# Altium schematic sheet Inteligent_IGBT.SchDoc
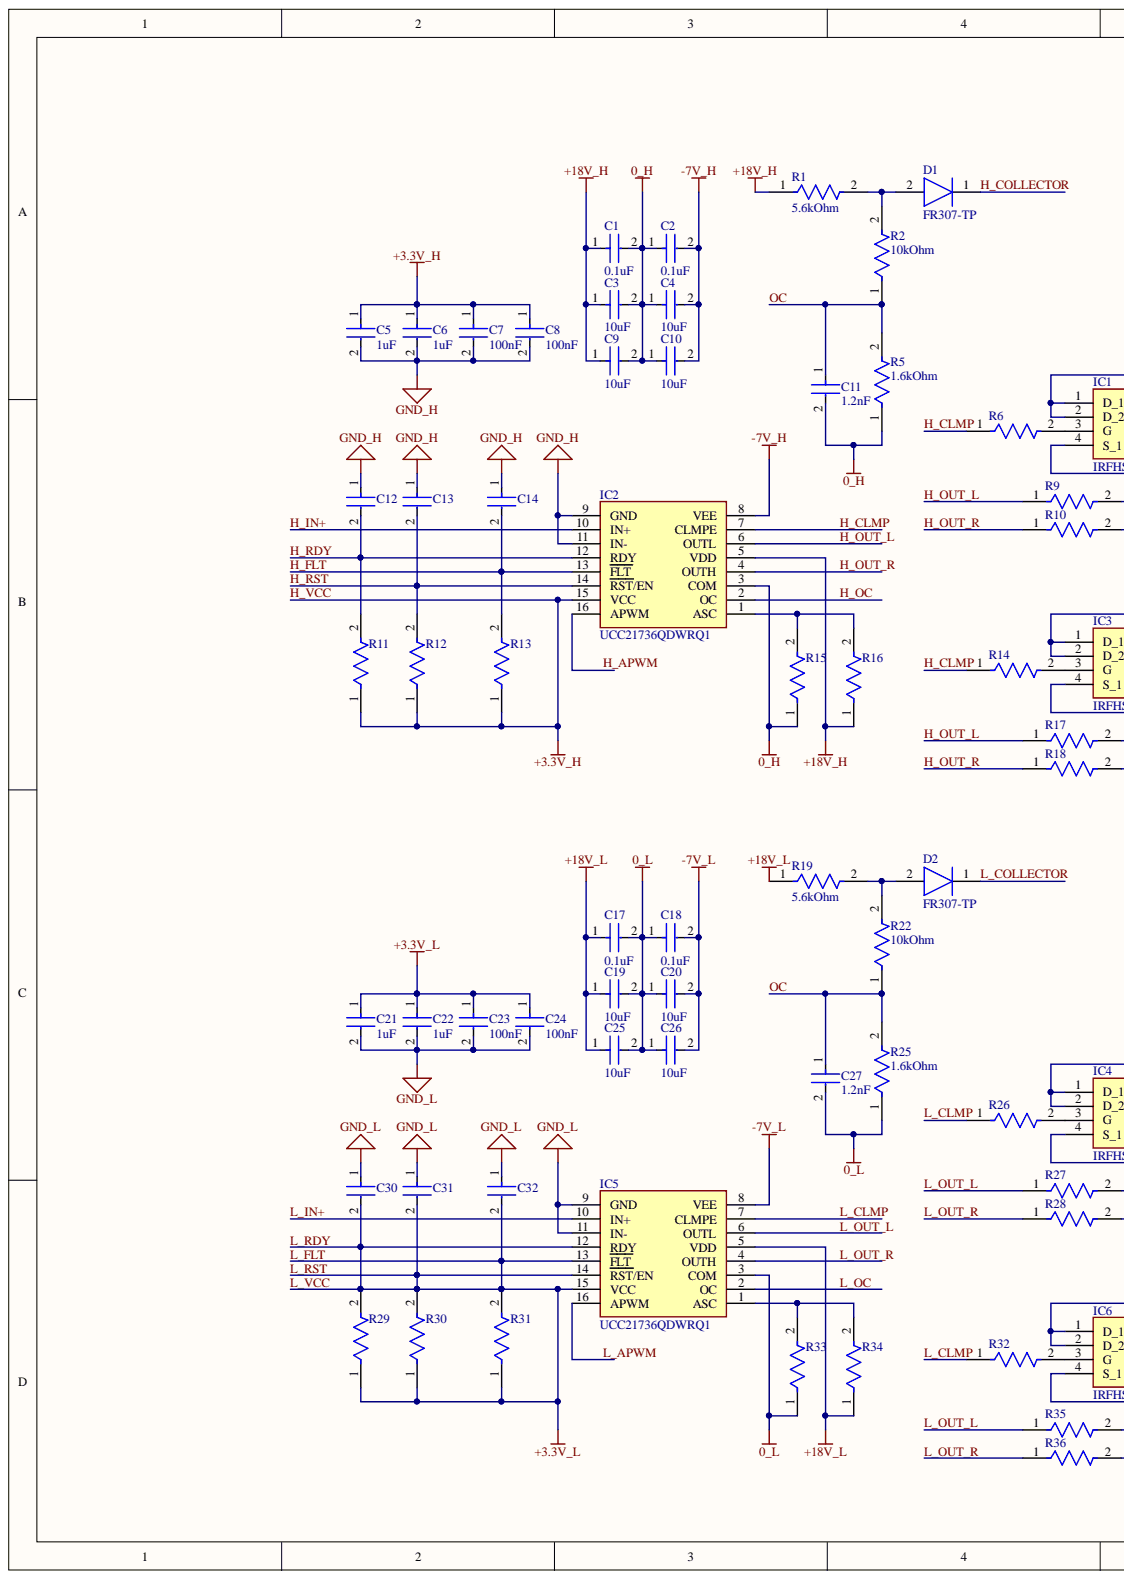
[diagram p0: Inteligent_IGBT.SchDoc, left]
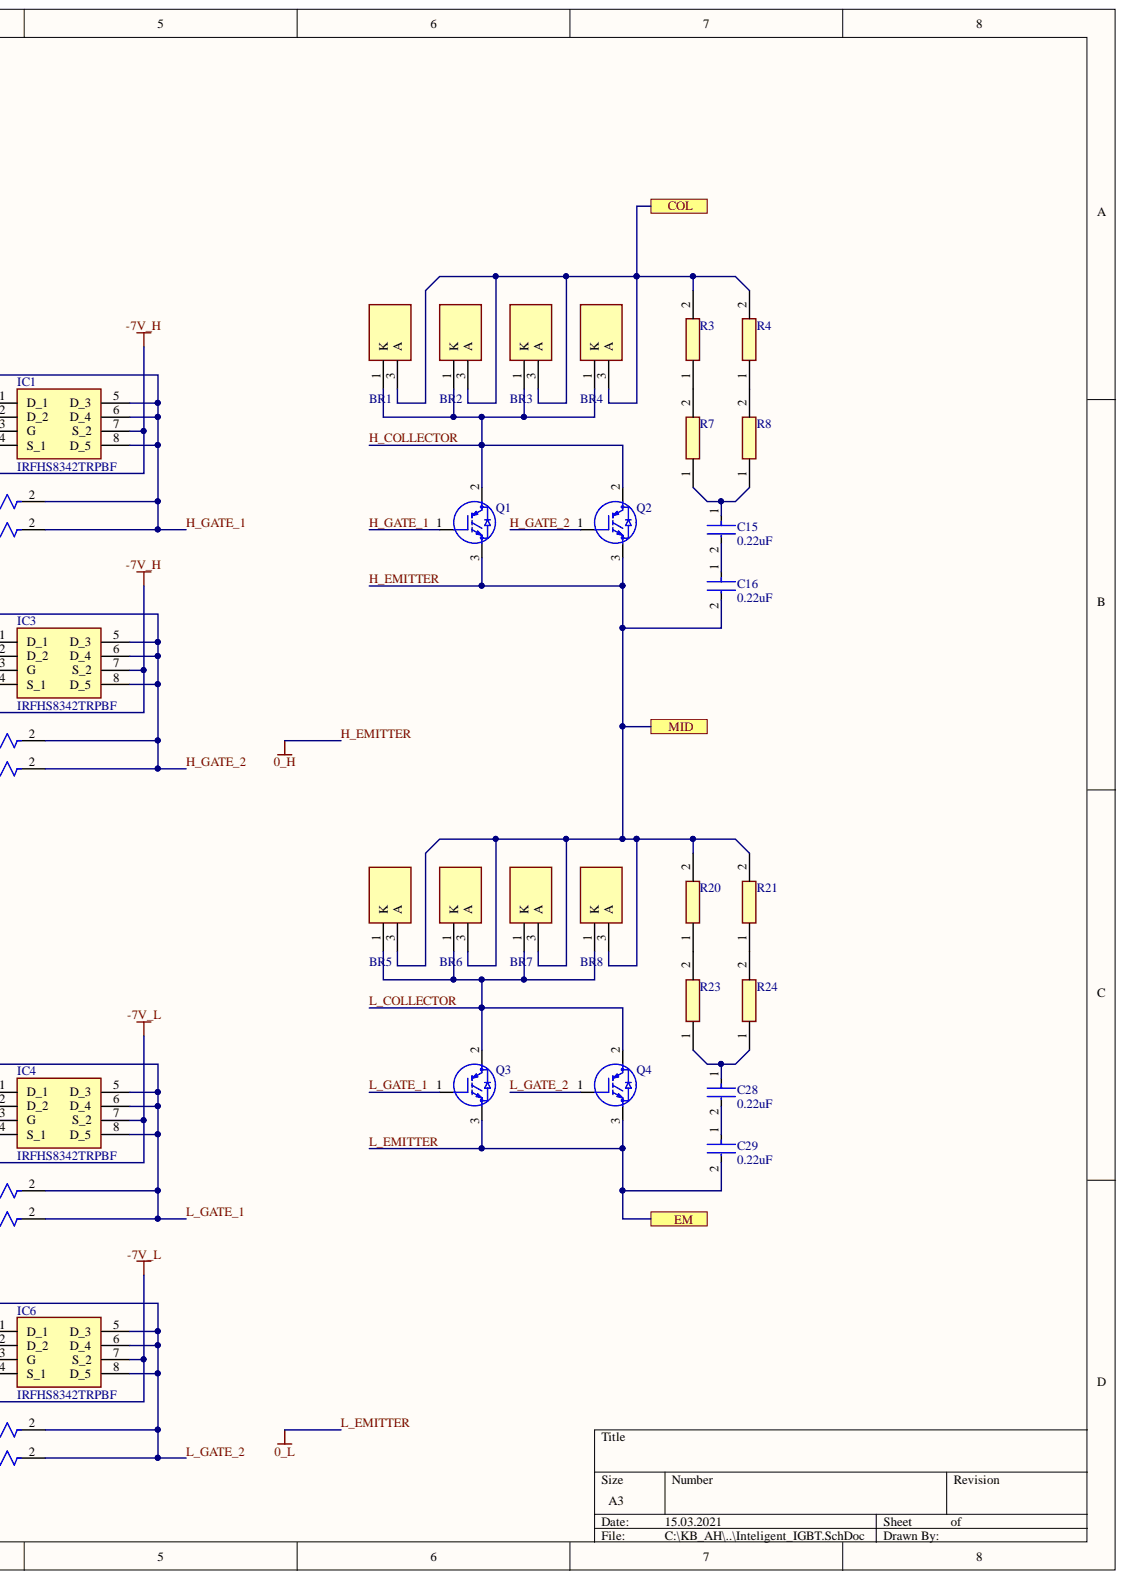
[diagram p1: Inteligent_IGBT.SchDoc, right]

## Parts
BR1  VS-75EPU12L-N3  [VS75EPU12LN3]  (value not drawn)
BR2  VS-75EPU12L-N3  [VS75EPU12LN3]  (value not drawn)
BR3  VS-75EPU12L-N3  [VS75EPU12LN3]  (value not drawn)
BR4  VS-75EPU12L-N3  [VS75EPU12LN3]  (value not drawn)
BR5  VS-75EPU12L-N3  [VS75EPU12LN3]  (value not drawn)
BR6  VS-75EPU12L-N3  [VS75EPU12LN3]  (value not drawn)
BR7  VS-75EPU12L-N3  [VS75EPU12LN3]  (value not drawn)
BR8  VS-75EPU12L-N3  [VS75EPU12LN3]  (value not drawn)
C1  0.1uF  [FP-CGA5L-0_3-0_1-IPC_B]
C2  0.1uF  [FP-CGA5L-0_3-0_1-IPC_B]
C3  10uF  [FP-CGA6P-IPC_B]
C4  10uF  [FP-CGA6P-IPC_B]
C5  1uF  [FP-GCJ21B-0_3-IPC_B]
C6  1uF  [FP-GCJ21B-0_3-IPC_B]
C7  100nF  [FP-GCJ21B-0_3-IPC_B]
C8  100nF  [FP-GCJ21B-0_3-IPC_B]
C9  10uF  [FP-CGA6P-IPC_B]
C10  10uF  [FP-CGA6P-IPC_B]
C11  1.2nF  [FP-CGA4J-IPC_B]
C12  100pF  [FP-C0805C-DN-IPC_B]  (value not drawn)
C13  100pF  [FP-C0805C-DN-IPC_B]  (value not drawn)
C14  100pF  [FP-C0805C-DN-IPC_B]  (value not drawn)
C15  0.22uF  [FP-CKC33C-IPC_A]
C16  0.22uF  [FP-CKC33C-IPC_A]
C17  0.1uF  [FP-CGA5L-0_3-0_1-IPC_B]
C18  0.1uF  [FP-CGA5L-0_3-0_1-IPC_B]
C19  10uF  [FP-CGA6P-IPC_B]
C20  10uF  [FP-CGA6P-IPC_B]
C21  1uF  [FP-GCJ21B-0_3-IPC_B]
C22  1uF  [FP-GCJ21B-0_3-IPC_B]
C23  100nF  [FP-GCJ21B-0_3-IPC_B]
C24  100nF  [FP-GCJ21B-0_3-IPC_B]
C25  10uF  [FP-CGA6P-IPC_B]
C26  10uF  [FP-CGA6P-IPC_B]
C27  1.2nF  [FP-CGA4J-IPC_B]
C28  0.22uF  [FP-CKC33C-IPC_A]
C29  0.22uF  [FP-CKC33C-IPC_A]
C30  100pF  [FP-C0805C-DN-IPC_B]  (value not drawn)
C31  100pF  [FP-C0805C-DN-IPC_B]  (value not drawn)
C32  100pF  [FP-C0805C-DN-IPC_B]  (value not drawn)
D1  FR307-TP  [DIOAD2860W130L840D505]
D2  FR307-TP  [DIOAD2860W130L840D505]
IC1  IRFHS8342TRPBF  [PQFN(2X2)]
IC2  UCC21736QDWRQ1  [SOIC127P1030X265-16N]
IC3  IRFHS8342TRPBF  [PQFN(2X2)]
IC4  IRFHS8342TRPBF  [PQFN(2X2)]
IC5  UCC21736QDWRQ1  [SOIC127P1030X265-16N]
IC6  IRFHS8342TRPBF  [PQFN(2X2)]
Q1  IXYK110N120A4  [IXYK110N120A4]  (value not drawn)
Q2  IXYK110N120A4  [IXYK110N120A4]  (value not drawn)
Q3  IXYK110N120A4  [IXYK110N120A4]  (value not drawn)
Q4  IXYK110N120A4  [IXYK110N120A4]  (value not drawn)
R1  5.6kOhm  [FP-CRCW0805-e3-IPC_B]
R2  10kOhm  [FP-CRCW0805-e3-IPC_B]
R3  RCL12251K00FKEG  [RESC3263X80N]  (value not drawn)
R4  RCL12251K00FKEG  [RESC3263X80N]  (value not drawn)
R5  1.6kOhm  [FP-CRCW0805-e3-IPC_B]
R6  CRCW080522R0FKEA  [FP-CRCW0805-e3-IPC_C]  (value not drawn)
R7  RCL12251K00FKEG  [RESC3263X80N]  (value not drawn)
R8  RCL12251K00FKEG  [RESC3263X80N]  (value not drawn)
R9  CRCW25124R70JNEG  [FP-CRCW2512-e3-IPC_A]  (value not drawn)
R10  CRCW25124R30JNEG  [FP-CRCW2512-e3-IPC_B]  (value not drawn)
R11  5.6kOhm  [FP-CRCW0805-e3-IPC_B]  (value not drawn)
R12  5.6kOhm  [FP-CRCW0805-e3-IPC_B]  (value not drawn)
R13  5.6kOhm  [FP-CRCW0805-e3-IPC_B]  (value not drawn)
R14  CRCW080522R0FKEA  [FP-CRCW0805-e3-IPC_C]  (value not drawn)
R15  5.6kOhm  [FP-CRCW0805-e3-IPC_B]  (value not drawn)
R16  10kOhm  [FP-CRCW0805-e3-IPC_B]  (value not drawn)
R17  CRCW25124R70JNEG  [FP-CRCW2512-e3-IPC_A]  (value not drawn)
R18  CRCW25124R30JNEG  [FP-CRCW2512-e3-IPC_B]  (value not drawn)
R19  5.6kOhm  [FP-CRCW0805-e3-IPC_B]
R20  RCL12251K00FKEG  [RESC3263X80N]  (value not drawn)
R21  RCL12251K00FKEG  [RESC3263X80N]  (value not drawn)
R22  10kOhm  [FP-CRCW0805-e3-IPC_B]
R23  RCL12251K00FKEG  [RESC3263X80N]  (value not drawn)
R24  RCL12251K00FKEG  [RESC3263X80N]  (value not drawn)
R25  1.6kOhm  [FP-CRCW0805-e3-IPC_B]
R26  CRCW080522R0FKEA  [FP-CRCW0805-e3-IPC_C]  (value not drawn)
R27  CRCW25124R70JNEG  [FP-CRCW2512-e3-IPC_A]  (value not drawn)
R28  CRCW25124R30JNEG  [FP-CRCW2512-e3-IPC_B]  (value not drawn)
R29  5.6kOhm  [FP-CRCW0805-e3-IPC_B]  (value not drawn)
R30  5.6kOhm  [FP-CRCW0805-e3-IPC_B]  (value not drawn)
R31  5.6kOhm  [FP-CRCW0805-e3-IPC_B]  (value not drawn)
R32  CRCW080522R0FKEA  [FP-CRCW0805-e3-IPC_C]  (value not drawn)
R33  5.6kOhm  [FP-CRCW0805-e3-IPC_B]  (value not drawn)
R34  10kOhm  [FP-CRCW0805-e3-IPC_B]  (value not drawn)
R35  CRCW25124R70JNEG  [FP-CRCW2512-e3-IPC_A]  (value not drawn)
R36  CRCW25124R30JNEG  [FP-CRCW2512-e3-IPC_B]  (value not drawn)

## Ports
COL, EM, MID

## Nets
+18V_H: C1.1 C3.1 C9.1 IC2.5(VDD) R1.1 R16.1
+18V_L: C17.1 C19.1 C25.1 IC5.5(VDD) R19.1 R34.1
-7V_H: C2.2 C4.2 C10.2 IC1.4(S_1) IC1.7(S_2) IC2.8(VEE) IC3.4(S_1) IC3.7(S_2)
-7V_L: C18.2 C20.2 C26.2 IC4.4(S_1) IC4.7(S_2) IC5.8(VEE) IC6.4(S_1) IC6.7(S_2)
GND_H: C5.2 C6.2 C7.2 C8.2 C12.1 C13.1 C14.1 IC2.9(GND) IC2.11(IN-)
GND_L: C21.2 C22.2 C23.2 C24.2 C30.1 C31.1 C32.1 IC5.9(GND) IC5.11(IN-)
COL: BR1.3 BR2.3 BR3.3 BR4.3 R3.2 R4.2 ; port COL
H_APWM: IC2.16(APWM)
H_CLMP: IC2.7(CLMPE) R6.1 R14.1
H_COLLECTOR: BR1.1 BR2.1 BR3.1 BR4.1 D1.1 Q1.2 Q2.2
H_EMITTER: BR5.3 BR6.3 BR7.3 BR8.3 C1.2 C2.1 C3.2 C4.1 C9.2 C10.1 C11.2 C16.2 IC2.3(COM) Q1.3 Q2.3 R5.1 R15.1 R20.2 R21.2 ; port MID
H_FLT: C14.2 IC2.13(FLT) R13.2
H_GATE_1: IC1.1(D_1) IC1.2(D_2) IC1.5(D_3) IC1.6(D_4) IC1.8(D_5) Q1.1 R9.2 R10.2
H_GATE_2: IC3.1(D_1) IC3.2(D_2) IC3.5(D_3) IC3.6(D_4) IC3.8(D_5) Q2.1 R17.2 R18.2
H_IN+: IC2.10(IN+)
H_OC: IC2.2(OC)
H_OUT_L: IC2.6(OUTL) R9.1 R17.1
H_OUT_R: IC2.4(OUTH) R10.1 R18.1
H_RDY: C12.2 IC2.12(RDY) R11.2
H_RST: C13.2 IC2.14(RST/EN) R12.2
H_VCC: C5.1 C6.1 C7.1 C8.1 IC2.15(VCC) R11.1 R12.1 R13.1
L_APWM: IC5.16(APWM)
L_CLMP: IC5.7(CLMPE) R26.1 R32.1
L_COLLECTOR: BR5.1 BR6.1 BR7.1 BR8.1 D2.1 Q3.2 Q4.2
L_EMITTER: C17.2 C18.1 C19.2 C20.1 C25.2 C26.1 C27.2 C29.2 IC5.3(COM) Q3.3 Q4.3 R25.1 R33.1 ; port EM
L_FLT: C21.1 C22.1 C23.1 C24.1 C30.2 C31.2 C32.2 IC5.12(RDY) IC5.13(FLT) IC5.14(RST/EN) IC5.15(VCC) R29.1 R29.2 R30.1 R30.2 R31.1 R31.2
L_GATE_1: IC4.1(D_1) IC4.2(D_2) IC4.5(D_3) IC4.6(D_4) IC4.8(D_5) Q3.1 R27.2 R28.2
L_GATE_2: IC6.1(D_1) IC6.2(D_2) IC6.5(D_3) IC6.6(D_4) IC6.8(D_5) Q4.1 R35.2 R36.2
L_IN+: IC5.10(IN+)
L_OC: IC5.2(OC)
L_OUT_L: IC5.6(OUTL) R27.1 R35.1
L_OUT_R: IC5.4(OUTH) R28.1 R36.1
OC: C11.1 C27.1 R2.1 R5.2 R22.1 R25.2
NetC15_1: C15.1 R7.1 R8.1
NetC15_2: C15.2 C16.1
NetC28_1: C28.1 R23.1 R24.1
NetC28_2: C28.2 C29.1
NetD1_2: D1.2 R1.2 R2.2
NetD2_2: D2.2 R19.2 R22.2
NetIC1_3: IC1.3(G) R6.2
NetIC2_1: IC2.1(ASC) R15.2 R16.2
NetIC3_3: IC3.3(G) R14.2
NetIC4_3: IC4.3(G) R26.2
NetIC5_1: IC5.1(ASC) R33.2 R34.2
NetIC6_3: IC6.3(G) R32.2
NetR3_1: R3.1 R7.2
NetR4_1: R4.1 R8.2
NetR20_1: R20.1 R23.2
NetR21_1: R21.1 R24.2

## Library data of the parts
BR1-BR8 = VS-75EPU12L-N3: Bridge Rectifier; Manufacturer_Name: Vishay; Arrow Part Number: VS-75EPU12L-N3; Arrow Price/Stock: https://www.arrow.com/en/products/vs-75epu12l-n3/vishay; Component Kind: Standard; Datasheet Link: https://datasheet.lcsc.com/szlcsc/1811271814_Vishay-Intertech-VS-75EPU12L-N3_C335787.pdf; Height: 24.99mm; Manufacturer_Part_Number: VS-75EPU12L-N3; Mouser Part Number: 78-VS-75EPU12L-N3; Mouser Price/Stock: https://www.mouser.co.uk/ProductDetail/Vishay-Semiconductors/VS-75EPU12L-N3?qs=AfeIN4OqR0CECNyPZBJseQ%3D%3D
C1 C2 C17 C18 = CMP-08246-000628-1: Cap Ceramic 100nF 50V NP0 5% Pad SMD 1206 +150°C Automotive T/R; Capacitance: 100nF; Case/Package: 1206; Case Code (Imperial): 1206; Case Code (Metric): 3216; Component Kind: Standard; Features: High Temperature; Length: 0.126inch; Library Name: Altium Content Vault; Max Operating Temperature: 150°C; Min Operating Temperature: -55°C; Mount: Surface Mount; Package Quantity: 2000; Packaging: Tape and Reel; Ratings: AEC-Q200; Thickness: 0.071inch; Tolerance: 5%; Voltage Rating: 50V; Width: 0.063inch
C3 C4 C9 C10 C19 C20 C25 C26 = CMP-08246-001494-1: Cap Ceramic 10uF 25V X8R 10% Pad SMD 1210 +150°C Automotive T/R; Capacitance: 10uF; Case/Package: 1210; Case Code (Imperial): 1210; Case Code (Metric): 3225; Component Kind: Standard; Features: High Temperature; Library Name: Altium Content Vault; Max Operating Temperature: 150°C; Min Operating Temperature: -55°C; Mount: Surface Mount; Packaging: Cut Tape; Ratings: AEC-Q200; Tolerance: 10%; Voltage Rating: 25V
C5 C6 C21 C22 = CMP-06035-029661-1: Multilayer Ceramic Capacitors MLCC - SMD/SMT 0805 1uF 10%, 25VDC,  X8L +15/-40%; Voltage Rating: 25V; Capacitance: 1uF; Case/Package: 0805; Case Code (Imperial): 0805; Case Code (Metric): 2012; Component Kind: Standard; Features: Soft Termination; Library Name: Altium Content Vault; Max Operating Temperature: 150°C; Min Operating Temperature: -55°C; Mount: Surface Mount; Packaging: Tape and Reel; Ratings: AEC-Q200; Tolerance: 10%
C7 C8 C23 C24 = CMP-06035-032475-1: Multilayer Ceramic Capacitors MLCC - SMD/SMT 0805 0.1uF 10%, 50VDC,  X8L +15/-40%; Voltage Rating: 50V; Capacitance: 100nF; Case/Package: 0805; Case Code (Imperial): 0805; Case Code (Metric): 2012; Component Kind: Standard; Features: Soft Termination; Library Name: Altium Content Vault; Max Operating Temperature: 150°C; Min Operating Temperature: -55°C; Mount: Surface Mount; Packaging: Tape and Reel; Ratings: AEC-Q200; Tolerance: 10%
C11 C27 = CMP-08246-000791-1: Cap Ceramic 1.2nF 450V NP0 5% Pad SMD 0805 +150°C Automotive T/R; Dielectric: C0G; Capacitance: 1.2nF; Case/Package: 0805; Case Code (Imperial): 0805; Case Code (Metric): 2012; Component Kind: Standard; Composition: Ceramic; Features: High Temperature; Height: 0.6mm; Lead Free: Lead Free; Length: 0.079inch; Library Name: Altium Content Vault; Max Operating Temperature: 150°C; Min Operating Temperature: -55°C; Mount: Surface Mount; Package Quantity: 4000; Packaging: Tape and Reel; Ratings: AEC-Q200; Termination: SMD/SMT; Thickness: 0.03inch; Tolerance: 5%; Voltage Rating: 450V; Voltage Rating (DC): 450V; Weight: 0.000194oz; Width: 0.049inch
C12-C14 C30-C32 = CMP-03020-020342-1: C0805 100 pF X8R 30ppm/°C 5.00% 50 V; Manufacturer: KEMET; Manufacturer Part Number: C0805C101J5HACAUTO; Material: Ceramic; Tolerance: 0.05; Voltage Rating: 50 V; Automotive: No; Capacitance: 100 pF; Category: Cap; Component Kind: Standard; ComponentLink2Description: Datasheet; ComponentLink2URL: https://content.kemet.com/datasheets/KEM_C1003_C0G_SMD.pdf; Device Class L1: Passive Components; Device Class L2: Capacitors; Device Class L3: Ceramic Capacitors; Digikey Description: CAP CER 0805 100PF 50V ULTRA STA; Height: 0.88mm; Ipc Land Pattern Name: CAPC200125X78; Lead Free: Yes; Library Name: Altium Content Vault; Mouser Description: Cap Ceramic 100pF 50V X8R 5% Pad SMD 0805 150C Automotive T/R; Octopart: https://octopart.com/c0805c101j5hacauto-kemet-87698063; Package: C0805C-DN; RoHS: TRUE; Temperature: -55°C to +125°C; Temperature Characteristic: X8R; Temperature Coefficient: 30ppm/°C; Temperature Range High: +125°C; Temperature Range Low: -55°C; Value: 100 pF
C15 C16 C28 C29 = CMP-03020-010018-1: CKC33C 3640 C0G 30ppm/°C 10.00% 500V; Manufacturer: KEMET; Manufacturer Part Number: CKC33C224KCGACAUTO; Automotive Grade: Grade 0; Material: Ceramic; Tolerance: 0.1; Automotive: Yes; Capacitance: 0.22 uF; Category: Cap; Component Kind: Standard; ComponentLink2Description: Datasheet; ComponentLink2URL: https://content.kemet.com/datasheets/KEM_C1039_KC-LINK_C0G.pdf; Device Class L1: Passive Components; Device Class L2: Capacitors; Device Class L3: Ceramic Capacitors; Digikey Description: KC-LINK 3640 220NF 500V C0G; Height: 2.7mm; Ipc Land Pattern Name: CAPC9301020X250; Lead Free: Yes; Library Name: Altium Content Vault; Mouser Description: Cap Ceramic 0.22uF 500V C0G 10% Pad SMD 3640 150C Automotive T/R; Octopart: https://octopart.com/ckc33c224kcgacauto-kemet-88954723; Package: CKC33C; RoHS: TRUE; Temperature: -55°C to +150°C; Temperature Characteristic: C0G; Temperature Coefficient: 30ppm/°C; Temperature Range High: +150°C; Temperature Range Low: -55°C; Value: 0.22 uF; Voltage Rating: 500V
D1 D2 = FR307-TP: Diode; Manufacturer_Name: Micro Commercial Components (MCC); Arrow Part Number: FR307-TP; Arrow Price/Stock: https://www.arrow.com/en/products/fr307-tp/micro-commercial-components; Component Kind: Standard; Datasheet Link: http://www.mccsemi.com/up_pdf/FR301-FR307(DO-201AD).pdf; Manufacturer_Part_Number: FR307-TP; Mouser Part Number: 833-FR307-TP; Mouser Price/Stock: https://www.mouser.com/Search/Refine.aspx?Keyword=833-FR307-TP
IC1 IC3 IC4 IC6 = IRFHS8342TRPBF: Integrated Circuit; Manufacturer_Name: Infineon; Arrow Part Number: IRFHS8342TRPBF; Arrow Price/Stock: https://www.arrow.com/en/products/irfhs8342trpbf/infineon-technologies-ag; Component Kind: Standard; Datasheet Link: https://componentsearchengine.com/Datasheets/1/IRFHS8342TRPBF.pdf; Manufacturer_Part_Number: IRFHS8342TRPBF; Mouser Part Number: 942-IRFHS8342TRPBF; Mouser Price/Stock: https://www.mouser.co.uk/ProductDetail/Infineon-IR/IRFHS8342TRPBF?qs=Z8%252BeY1k3TILJK9l3AOTdfA%3D%3D
IC2 IC5 = UCC21736QDWRQ1: Integrated Circuit; Manufacturer_Name: Texas Instruments; Component Kind: Standard; Datasheet Link: https://www.ti.com/lit/ds/symlink/ucc21736-q1.pdf?ts=1610170637293&ref_url=https%253A%252F%252Feu.mouser.com%252F; Height: 2.65mm; Manufacturer_Part_Number: UCC21736QDWRQ1; Mouser Part Number: 595-UCC21736QDWRQ1; Mouser Price/Stock: https://www.mouser.co.uk/ProductDetail/Texas-Instruments/UCC21736QDWRQ1/?qs=xZ%2FP%252Ba9zWqazxpYJ%2Flne3g%3D%3D
Q1-Q4 = IXYK110N120A4: Transistor IGBT; Manufacturer_Name: IXYS SEMICONDUCTOR; Component Kind: Standard; Datasheet Link: https://www.littelfuse.com/~/media/electronics/datasheets/discrete_igbts/littelfuse_discrete_igbts_xpt_ixyk110n120a4_datasheet.pdf.pdf; Height: 5.31mm; Manufacturer_Part_Number: IXYK110N120A4
R1 R11-R13 R15 R19 R29-R31 R33 = CMP-2001-04030-2: RES Thick Film, 5.6kΩ, 1%, 0.125W, 100ppm/°C, 0805; Max Power Dissipation: 125mW; Resistance: 5.6kR; Voltage Rating: 150V; Case/Package: 0805; Case Code (Imperial): 0805; Case Code (Metric): 2012; Component Kind: Standard; Composition: Thick Film; Contact Plating: Tin; Depth: 1.25mm; Features: Automotive AEC-Q200; Height: 0.45mm; Lead Free: Lead Free; Length: 2mm; Library Name: Altium Content Vault; Max Operating Temperature: 155°C; Military Standard: Not; Min Operating Temperature: -55°C; Mount: Surface Mount; Number of Pins: 2; Number of Terminations: 2; Package Quantity: 1; Packaging: Tape and Reel; Power Rating: 125mW; Radiation Hardening: No; Temperature Coefficient: 100ppm/°C; Termination: SMD/SMT; Tolerance: 1%; Voltage Rating (DC): 150V; Weight: 0.000194oz; Width: 1.25mm
R2 R16 R22 R34 = CMP-2001-04908-2: RES Thick Film, 10kΩ, 1%, 0.125W, 100ppm/°C, 0805; Power Rating: 125mW; Resistance: 10kR; Voltage Rating: 150V; Case/Package: 0805; Case Code (Imperial): 0805; Case Code (Metric): 2012; Component Kind: Standard; Composition: Thick Film; Contact Plating: Tin; Depth: 1.25mm; Height: 0.45mm; Lead Free: Lead Free; Length: 2mm; Library Name: Altium Content Vault; Max Operating Temperature: 155°C; Military Standard: Not; Min Operating Temperature: -55°C; Package Quantity: 5000; Packaging: Tape and Reel; Radiation Hardening: No; Temperature Coefficient: 100ppm/°C; Termination: SMD/SMT; Tolerance: 1%; Voltage Rating (DC): 150V; Weight: 0.000194oz; Width: 1.25mm
R3 R4 R7 R8 R20 R21 R23 R24 = RCL12251K00FKEG: Resistor; Manufacturer_Name: Vishay; Component Kind: Standard; Datasheet Link: https://www.mouser.com/datasheet/2/427/rcle3-247384.pdf; Height: 0.8mm; Manufacturer_Part_Number: RCL12251K00FKEG; Mouser Part Number: 71-RCL12251K00FKEG; Mouser Price/Stock: https://www.mouser.com/Search/Refine.aspx?Keyword=71-RCL12251K00FKEG
R5 R25 = CMP-1013-00079-3: RES Thick Film, 1.6kΩ, 5%, 0.125W, 200ppm/°C, 0805; Max Power Dissipation: 125mW; Resistance: 1.6kR; Voltage Rating: 150V; Case/Package: 0805; Case Code (Imperial): 0805; Case Code (Metric): 2012; Component Kind: Standard; Composition: Thick Film; Contact Plating: Tin; Depth: 1.25mm; Features: Automotive AEC-Q200; Height: 0.02inch; Lead Free: Lead Free; Length: 2mm; Library Name: Altium Content Vault; Max Operating Temperature: 155°C; Military Standard: Not; Min Operating Temperature: -55°C; Number of Terminations: 2; Package Quantity: 1; Packaging: Tape and Reel; Power Rating: 125mW; Radiation Hardening: No; Temperature Coefficient: 200ppm/°C; Tolerance: 5%; Voltage Rating (DC): 150V; Weight: 0.000194oz; Width: 0.049inch
R6 R14 R26 R32 = CMP-2001-03692-2: RES Thick Film, 22Ω, 1%, 0.125W, 100ppm/°C, 0805; Max Power Dissipation: 125mW; Resistance: 22R; Voltage Rating: 150V; Case/Package: 0805; Case Code (Imperial): 0805; Case Code (Metric): 2012; Component Kind: Standard; Composition: Thick Film; Contact Plating: Tin; Depth: 1.25mm; Features: Automotive AEC-Q200; Height: 0.45mm; Lead Free: Lead Free; Length: 2mm; Library Name: Altium Content Vault; Max Operating Temperature: 155°C; Military Standard: Not; Min Operating Temperature: -55°C; Mount: Surface Mount; Number of Terminations: 2; Package Quantity: 5000; Packaging: Tape and Reel; Power Rating: 125mW; Radiation Hardening: No; Temperature Coefficient: 100ppm/°C; Termination: SMD/SMT; Tolerance: 1%; Voltage Rating (DC): 150V; Weight: 0.000194oz; Width: 1.25mm
R9 R17 R27 R35 = CMP-1018-00018-2: RES Thick Film, 4.7Ω, 5%, 1W, 200ppm/°C, 2512; Resistance: 4.7R; Voltage Rating: 500V; Case/Package: 2512; Case Code (Imperial): 2512; Case Code (Metric): 6432; Component Kind: Standard; Composition: Thick Film; Contact Plating: Tin; Depth: 3.15mm; Features: Automotive AEC-Q200; Height: 0.6mm; Lead Free: Lead Free; Length: 6.3mm; Library Name: Altium Content Vault; Max Operating Temperature: 155°C; Max Power Dissipation: 1W; Military Standard: Not; Min Operating Temperature: -55°C; Mount: Surface Mount; Number of Pins: 2; Number of Terminations: 2; Package Quantity: 2000; Packaging: Tape and Reel; Power Rating: 1W; Radiation Hardening: No; Temperature Coefficient: 200ppm/°C; Termination: SMD/SMT; Tolerance: 5%; Voltage Rating (DC): 500V; Weight: 0.001429oz; Width: 3.15mm
R10 R18 R28 R36 = CMP-1018-00017-2: RES Thick Film, 4.3Ω, 5%, 1W, 200ppm/°C, 2512; Resistance: 4.3R; Voltage Rating: 500V; Case/Package: 2512; Case Code (Imperial): 2512; Case Code (Metric): 6432; Component Kind: Standard; Composition: Thick Film; Contact Plating: Tin; Depth: 3.15mm; Features: Automotive AEC-Q200; Height: 0.028inch; Lead Free: Lead Free; Length: 6.3mm; Library Name: Altium Content Vault; Max Operating Temperature: 155°C; Max Power Dissipation: 1W; Military Standard: Not; Min Operating Temperature: -55°C; Number of Pins: 2; Number of Terminations: 2; Package Quantity: 2000; Packaging: Tape and Reel; Power Rating: 1W; Radiation Hardening: No; Temperature Coefficient: 200ppm/°C; Termination: SMD/SMT; Tolerance: 5%; Voltage Rating (DC): 500V; Weight: 0.001429oz; Width: 0.124inch
